# Altium schematic sheet Sheet3.SchDoc(Sheet3)
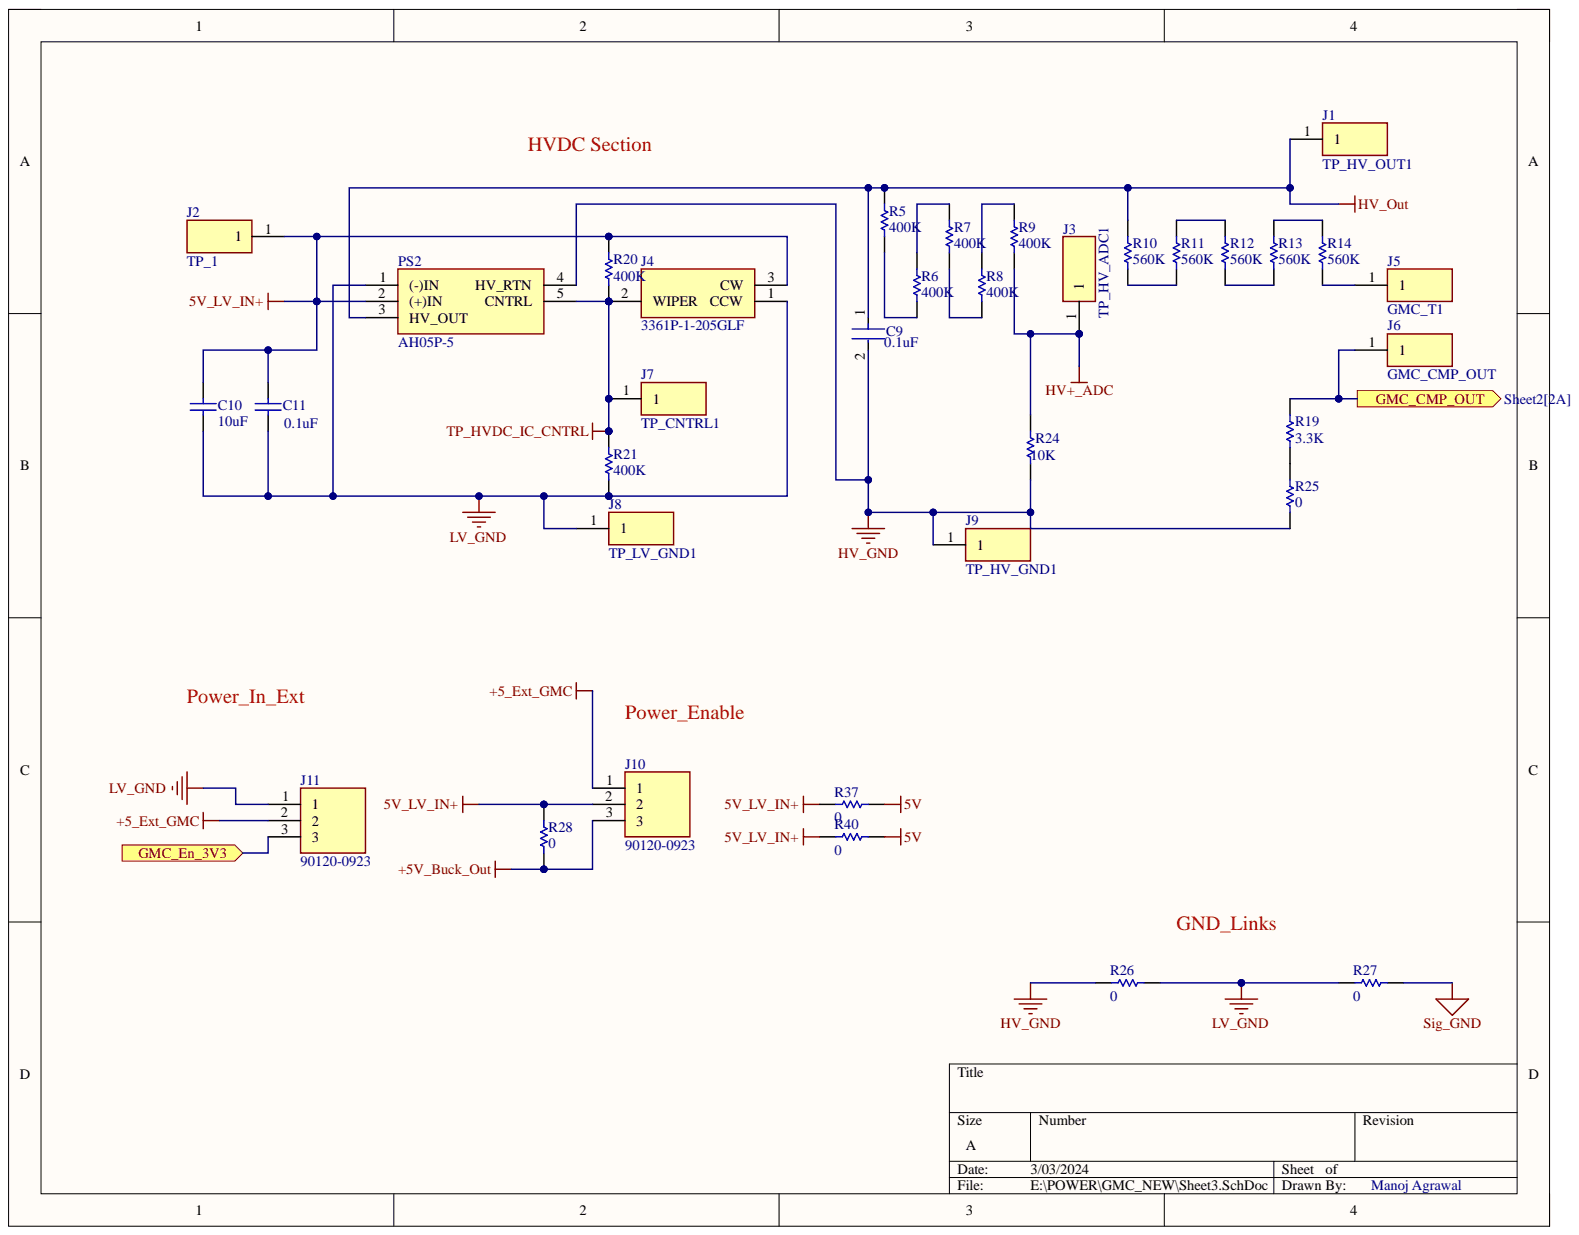
[diagram p0: Sheet3.SchDoc]

## Parts
C9  0.1uF  [FP-1210-L_3_3_0_4-W_2_5_0-IPC_B]
C10  10uF  [CAPC2013X88X50LL20T25]
C11  0.1uF  [CAPC2013X88X50LL20T25]
J1  TP_HV_OUT1  [HDRV1W64P0X254_1X1_254X248X838P]
J2  TP_1  [HDRV1W64P0X254_1X1_254X248X838P]
J3  TP_HV_ADC1  [HDRV1W64P0X254_1X1_254X248X838P]
J4  3361P-1-205GLF  [3361P1205GLF]
J5  GMC_T1  [HDRV1W64P0X254_1X1_254X248X838P]
J6  GMC_CMP_OUT  [HDRV1W64P0X254_1X1_254X248X838P]
J7  TP_CNTRL1  [HDRV1W64P0X254_1X1_254X248X838P]
J8  TP_LV_GND1  [HDRV1W64P0X254_1X1_254X248X838P]
J9  TP_HV_GND1  [HDRV1W64P0X254_1X1_254X248X838P]
J10  90120-0923  [HDRV3W90P0X254_1X3_762X249X560P]
J11  90120-0923  [HDRV3W90P0X254_1X3_762X249X560P]
PS2  AH05P-5  [AH05P5]
R5  400K  [RESC2013X50X30LL20T20]
R6  400K  [RESC2013X50X30LL20T20]
R7  400K  [RESC2013X50X30LL20T20]
R8  400K  [RESC2013X50X30LL20T20]
R9  400K  [RESC2013X50X30LL20T20]
R10  560K  [RESC2013X50X30LL20T20]
R11  560K  [RESC2013X50X30LL20T20]
R12  560K  [RESC2013X50X30LL20T20]
R13  560K  [RESC2013X50X30LL20T20]
R14  560K  [RESC2013X50X30LL20T20]
R19  3.3K  [RESC2013X50X30LL20T20]
R20  400K  [RESC2013X50X30LL20T20]
R21  400K  [RESC2013X50X30LL20T20]
R24  10K  [RESC2013X50X30LL20T20]
R25  0  [RESC2013X50X30LL20T20]
R26  0  [RESC2013X50X30LL20T20]
R27  0  [RESC2013X50X30LL20T20]
R28  0  [RESC2013X50X30LL20T20]
R37  0  [RESC2013X50X30LL20T20]
R40  0  [RESC2013X50X30LL20T20]

## Ports
GMC_CMP_OUT, GMC_En_3V3

## Nets
5V: J10.1 J10.3 J11.2 R28.2 R37.2 R40.2
5V_LV_IN+: C10.2 C11.2 J2.1 J4.3 J10.2 PS2.2((+)IN) R20.1 R28.1 R37.1 R40.1
HV+_ADC: J3.1 R9.2 R24.1
HV_GND: C9.2 J9.1 PS2.4(HV_RTN) R24.2 R25.2 R26.1
HV_Out: C9.1 J1.1 PS2.3(HV_OUT) R5.1 R10.2
LV_GND: C10.1 C11.1 J4.1 J8.1 J11.1 PS2.1((-)IN) R21.2 R26.2 R27.1
Sig_GND: R27.2
TP_HVDC_IC_CNTRL: J4.2 J7.1 PS2.5(CNTRL) R20.2 R21.1
GMC_CMP_OUT: J6.1 R19.1 ; port GMC_CMP_OUT
GMC_En_3V3: J11.3 ; port GMC_En_3V3
NetJ5_1: J5.1 R14.1
NetR5_2: R5.2 R6.2
NetR6_1: R6.1 R7.1
NetR7_2: R7.2 R8.2
NetR8_1: R8.1 R9.1
NetR10_1: R10.1 R11.1
NetR11_2: R11.2 R12.2
NetR12_1: R12.1 R13.1
NetR13_2: R13.2 R14.2
NetR19_2: R19.2 R25.1

## Library data of the parts
C9 = CMP-1038-02104-3: General Purpose Ceramic Capacitor, 1210, 2.2uF, 10%, X7R, 15%, 100V; Dissipation Factor: 2.5%; Capacitance: 2.2uF; Case Code (Imperial): 1210; Case Code (Metric): 3225; Case/Package: 1210; Component Kind: Standard; Construction: Flat; Contact Plating: Tin; Depth: 2.5mm; Dielectric: X7R; Height: 2.79mm; Lead Free: Lead Free; Length: 3.2mm; Library Name: Altium Content Vault; Max Operating Temperature: 125°C; Min Operating Temperature: -55°C; Mount: Surface Mount; Number of Pins: 2; Package Quantity: 1; Packaging: Tape and Reel; Radiation Hardening: No; REACH SVHC: No SVHC; RoHS Compliant: Yes; Series: -; Termination: SMD/SMT; Thickness: 0.11inch; Tolerance: 10%; Voltage: 100V; Voltage Rating: 100V; Voltage Rating (DC): 100V; Width: 2.5mm
C10 C11 = CMP-2007-00266-1: Manufacturer: Kemet; Part Number: C0805C103K5RACTU; Component Kind: Standard; Library Name: Altium Content Vault
J1-J3 J5-J9 = HTSW-101-07-L-S: Connector; Manufacturer_Name: SAMTEC; Arrow Part Number: HTSW-101-07-L-S; Arrow Price/Stock: https://www.arrow.com/en/products/htsw-101-07-l-s/samtec; Component Kind: Standard; Datasheet Link: https://www.arrow.com/en/products/htsw-101-07-l-s/samtec; Height: 8.38mm; Library Name: OBC3.0.SchLib; Manufacturer_Part_Number: HTSW-101-07-L-S; Mouser Part Number: 200-HTSW10107LS; Mouser Price/Stock: https://www.mouser.co.uk/ProductDetail/Samtec/HTSW-101-07-L-S?qs=0lQeLiL1qybicCfG80ZrYQ%3D%3D
J4 = 3361P-1-205GLF: Connector; Manufacturer_Name: Bourns; Component Kind: Standard; Datasheet Link: https://componentsearchengine.com/Datasheets/1/3361P-1-205GLF.pdf; Height: 5.84mm; Manufacturer_Part_Number: 3361P-1-205GLF; Mouser Part Number: 652-3361P-1-205GLF; Mouser Price/Stock: https://www.mouser.co.uk/ProductDetail/Bourns/3361P-1-205GLF?qs=ia4AKmiMHMr19OwPydiPPg%3D%3D
J10 J11 = 90120-0923: Connector; Manufacturer_Name: Molex; Arrow Part Number: 90120-0923; Arrow Price/Stock: https://www.arrow.com/en/products/90120-0923/molex; Component Kind: Standard; Datasheet Link: https://www.molex.com/webdocs/datasheets/pdf/en-us/0901200923_PCB_HEADERS.pdf; Height: 5.6mm; Manufacturer_Part_Number: 90120-0923; Mouser Part Number: 538-90120-0923; Mouser Price/Stock: https://www.mouser.co.uk/ProductDetail/Molex/90120-0923?qs=PvP5v7Eimsnghocwqx8SVQ%3D%3D
PS2 = AH05P-5: Power Supply; Manufacturer_Name: XP POWER; Component Kind: Standard; Datasheet Link: https://www.mouser.in/datasheet/2/942/SF_AH_Series-1508389.pdf; Height: 6.86mm; Manufacturer_Part_Number: AH05P-5; Mouser Part Number: 209-AH05P-5; Mouser Price/Stock: https://www.mouser.com/Search/Refine.aspx?Keyword=209-AH05P-5
R5-R14 R19-R21 R24-R28 R37 R40 = CMP-2001-04896-1: Manufacturer: Vishay Dale; Part Number: CRCW08050000Z0EA; Component Kind: Standard; Library Name: Altium Content Vault
